# Altium schematic sheet CV-power.SchDoc
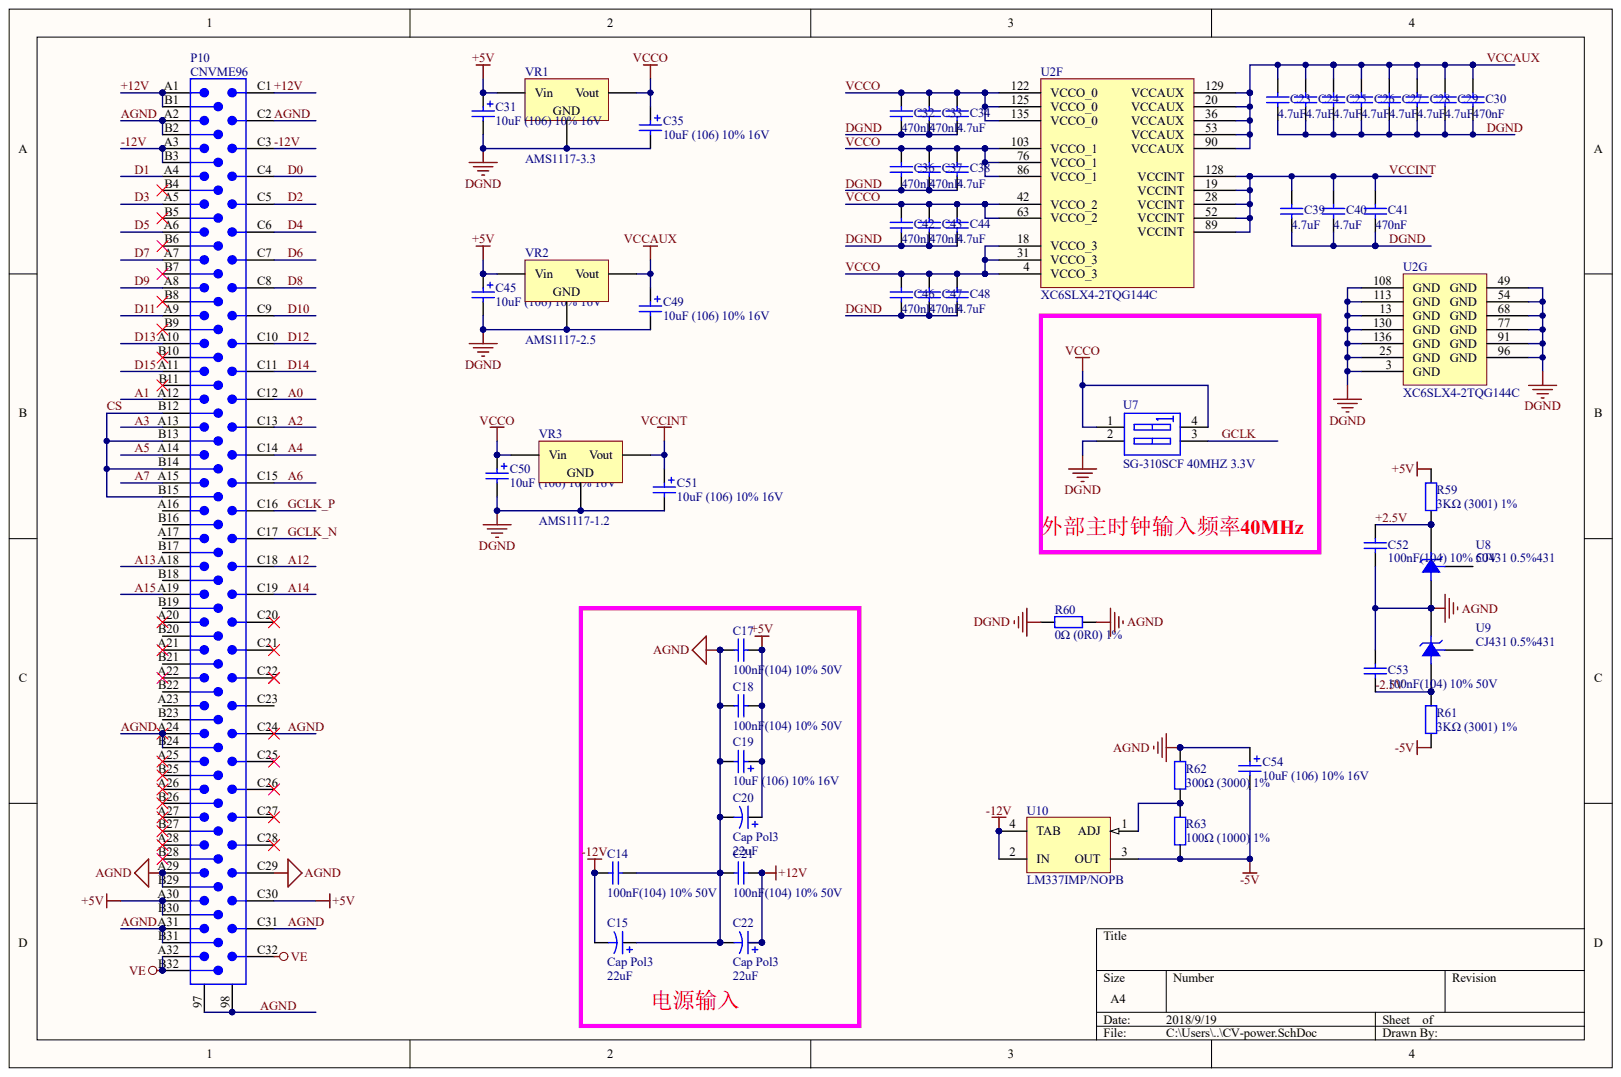
[diagram p0: CV-power.SchDoc]

## Parts
C14  100nF(104) 10% 50V
C15  Cap Pol3  value 22uF
C17  100nF(104) 10% 50V
C18  100nF(104) 10% 50V
C19  10uF (106) ±10% 16V
C20  Cap Pol3  value 22uF
C21  100nF(104) 10% 50V
C22  Cap Pol3  value 22uF
C23  4.7uF
C24  4.7uF
C25  4.7uF
C26  4.7uF
C27  4.7uF
C28  4.7uF
C29  4.7uF
C30  470nF
C31  10uF (106) ±10% 16V
C32  470nF
C33  470nF
C34  4.7uF
C35  10uF (106) ±10% 16V
C36  470nF
C37  470nF
C38  4.7uF
C39  4.7uF
C40  4.7uF
C41  470nF
C42  470nF
C43  470nF
C44  4.7uF
C45  10uF (106) ±10% 16V
C46  470nF
C47  470nF
C48  4.7uF
C49  10uF (106) ±10% 16V
C50  10uF (106) ±10% 16V
C51  10uF (106) ±10% 16V
C52  100nF(104) 10% 50V
C53  100nF(104) 10% 50V
C54  10uF (106) ±10% 16V
P10  CNVME96
R59  3KΩ (3001) ±1%  (value not drawn)
R60  0Ω (0R0) ±1%
R61  3KΩ (3001) ±1%  (value not drawn)
R62  300Ω (3000) ±1%
R63  100Ω (1000) ±1%
U2  XC6SLX4-2TQG144C  (parts F,G)
U7  SG-310SCF 40MHZ 3.3V
U8  CJ431 0.5%431
U9  CJ431 0.5%431
U10  LM337IMP/NOPB
VR1  AMS1117-3.3
VR2  AMS1117-2.5
VR3  AMS1117-1.2

## Nets
+5V: C17.2 C18.2 C19.1 C20.1 C31.1 C45.1 P10.A30 P10.B30 P10.C30 R59.2 VR1.3 VR2.3
-5V: C54.2 R61.1 R63.1 U10.3(OUT)
VE: P10.A32 P10.B32 P10.C32
+2.5V: C52.1 R59.1 U8.2
+12V: C21.2 C22.1 P10.A1 P10.B1 P10.C1
-2.5V: C53.2 R61.2 U9.3
-12V: C14.1 C15.2 P10.A3 P10.B3 P10.C3 U10.2(IN) U10.4(TAB)
A0: P10.C12
A1: P10.A12
A2: P10.C13
A3: P10.A13
A4: P10.C14
A5: P10.A14
A6: P10.C15
A7: P10.A15
A12: P10.C18
A13: P10.A18
A14: P10.C19
A15: P10.A19
AGND: C14.2 C15.1 C17.1 C18.1 C19.2 C20.2 C21.1 C22.2 C52.2 C53.1 C54.1 P10.97 P10.98 P10.A2 P10.A24 P10.A29 P10.A31 P10.B2 P10.B24 P10.B29 P10.B31 P10.C2 P10.C24 P10.C29 P10.C31 R60.2 R62.2 U8.3 U9.2
CS: P10.B12 P10.B13 P10.B14 P10.B15
D0: P10.C4
D1: P10.A4
D2: P10.C5
D3: P10.A5
D4: P10.C6
D5: P10.A6
D6: P10.C7
D7: P10.A7
D8: P10.C8
D9: P10.A8
D10: P10.C9
D11: P10.A9
D12: P10.C10
D13: P10.A10
D14: P10.C11
D15: P10.A11
DGND: C23.1 C24.1 C25.1 C26.1 C27.1 C28.1 C29.1 C30.1 C31.2 C32.1 C33.1 C34.1 C35.2 C36.1 C37.1 C38.1 C39.1 C40.1 C41.1 C42.1 C43.1 C44.1 C45.2 C46.1 C47.1 C48.1 C49.2 C50.2 C51.2 R60.1 U2.3(GND) U2.13(GND) U2.25(GND) U2.49(GND) U2.54(GND) U2.68(GND) U2.77(GND) U2.91(GND) U2.96(GND) U2.108(GND) U2.113(GND) U2.130(GND) U2.136(GND) U7.2 VR1.1 VR2.1 VR3.1
GCLK: U7.3
GCLK_N: P10.C17
GCLK_P: P10.C16
VCCAUX: C23.2 C24.2 C25.2 C26.2 C27.2 C28.2 C29.2 C30.2 C49.1 U2.20(VCCAUX) U2.36(VCCAUX) U2.53(VCCAUX) U2.90(VCCAUX) U2.129(VCCAUX) VR2.2
VCCINT: C39.2 C40.2 C41.2 C51.1 U2.19(VCCINT) U2.28(VCCINT) U2.52(VCCINT) U2.89(VCCINT) U2.128(VCCINT) VR3.2
VCCO: C32.2 C33.2 C34.2 C35.1 C36.2 C37.2 C38.2 C42.2 C43.2 C44.2 C46.2 C47.2 C48.2 C50.1 U2.4(VCCO_3) U2.18(VCCO_3) U2.31(VCCO_3) U2.42(VCCO_2) U2.63(VCCO_2) U2.76(VCCO_1) U2.86(VCCO_1) U2.103(VCCO_1) U2.122(VCCO_0) U2.125(VCCO_0) U2.135(VCCO_0) U7.1 U7.4 VR1.2 VR3.3
NetR62_1: R62.1 R63.2 U10.1(ADJ)

Not connected: P10 A16 A17 A20 A21 A22 A23 A25 A26 A27 A28 B4 B5 B6 B7 B8 B9 B10 B11 B16 B17 B18 B19 B20 B21 B22 B23 B25 B26 B27 B28 C20 C21 C22 C23 C25 C26 C27 C28; U8 1; U9 1

## Library data of the parts
C20 = (no symbol name): Value: 22uF; Published: 8-Jun-2000; LatestRevisionDate: 29-May-2009; LatestRevisionNote: IPC-7351 Footprint Added.; PackageReference: C0805; PackageDescription: Chip Capacitor; Publisher: Altium Limited
P10 = (no symbol name): Text Field6: Alternate Layout Available; Text Field7: Published 14-Feb-2002
U10 = (no symbol name): Manufacturer: National Semiconductor; PackageTopMarking: XYTT*N02A; PowerWise: N; CompType: LDO IC; Published: 1-Mar-2010; DatasheetVersion: Nov-2004; PackageVersion: revB, Apr-2003; PackageReference: MP04A; PackageDescription: Molded SOT-223, 6.50 x 3.56 x 1.6mm Body, 4 Lead, 2.3mm Pitch; Supplier 1: Digi-Key; Supplier Part Number 1: LM337IMPTR-ND; Supplier 2: Digi-Key; Supplier Part Number 2: LM337IMPCT-ND; Supplier 3: Digi-Key; Supplier Part Number 3: LM337IMPDKR-ND; Supplier 4: Newark; Supplier Part Number 4: 41K4742; PartNumber: LM337IMP/NOPB; RoHS: Y; PinCount: 4; Code_JEDEC: TO-261-AA; Code_IPC: SOT223-4; ComponentLink1Description: LM337 Datasheet; ComponentLink1URL: http://www.national.com/ds/LM/LM337.pdf; ComponentLink2Description: LM337 Web Page; ComponentLink2URL: http://www.national.com/pf/LM/LM337.html; ComponentLink3Description: MP04A Package Page; ComponentLink3URL: http://www.national.com/packaging/folders/mp04a.html; Publisher: Altium Limited
C14 C15 C17-C19 C21-C54 R59-R63 U2 U7-U9 VR1-VR3 = (no symbol name)
  C14 C17 C18 C21 C52 C53: ComponentLink1Description: 供应商链接; ComponentLink1URL: http://www.szlcsc.com/product/details_50686.html; Package: 0805; Supplier: LC; SuppliersPartNumber: C49678
  C15 C22: Published: 8-Jun-2000; LatestRevisionDate: 29-May-2009; LatestRevisionNote: IPC-7351 Footprint Added.; PackageReference: C0805; PackageDescription: Chip Capacitor
  C19 C31 C35 C45 C49-C51 C54: ComponentLink1Description: 供应商链接; ComponentLink1URL: http://www.szlcsc.com/product/details_7643.html; Package: 3216; Supplier: LC; SuppliersPartNumber: C7171
  C23-C29 C34 C38-C40 C44 C48: ComponentLink1Description: 供应商链接; ComponentLink1URL: http://www.szlcsc.com/product/details_2131.html; Package: 0805; Supplier: LC; SuppliersPartNumber: C1779
  C30 C32 C33 C36 C37 C41-C43 C46 C47: ComponentLink1Description: 供应商链接; ComponentLink1URL: http://www.szlcsc.com/product/details_14623.html; Package: 0805; Supplier: LC; SuppliersPartNumber: C13967
  R59 R61: ComponentLink1Description: 供应商链接; ComponentLink1URL: http://www.szlcsc.com/product/details_18349.html; Package: 0805; Supplier: LC; SuppliersPartNumber: C17661
  R60: ComponentLink1Description: 供应商链接; ComponentLink1URL: http://www.szlcsc.com/product/details_18165.html; Package: 0805; Supplier: LC; SuppliersPartNumber: C17477
  R62: ComponentLink1Description: 供应商链接; ComponentLink1URL: http://www.szlcsc.com/product/details_18305.html; Package: 0805; Supplier: LC; SuppliersPartNumber: C17617
  R63: ComponentLink1Description: 供应商链接; ComponentLink1URL: http://www.szlcsc.com/product/details_18096.html; Package: 0805; Supplier: LC; SuppliersPartNumber: C17408
  U2: Published: 1-Jul-2010; DatasheetVersion: ver1.6, Nov-2010; PackageVersion: ver1.2, Jun-2004; PackageReference: TQG144; PackageDescription: 144-Pin Thin Quad Flat Pack (TQG144) - Pb-Free; Supplier 1: Digi-Key; Supplier Part Number 1: XC6SLX4-2TQG144C-ND; Code_JEDEC: MS-026-BFB; ComponentLink1Description: Manufacturer Link; ComponentLink1URL: http://www.xilinx.com; ComponentLink2Description: Spartan-6 Datasheet; ComponentLink2URL: http://www.xilinx.com/support/documentation/data_sheets/ds160.pdf; ComponentLink3Description: Spartan-6 Documents; ComponentLink3URL: http://www.xilinx.com/support/documentation/spartan-6.htm; ComponentLink4Description: Xilinx.com Home; ComponentLink4URL: http://www.xilinx.com
  U7: Published: 24-Mar-1999; LatestRevisionDate: 17-Jul-2002; LatestRevisionNote: Re-released for DXP Platform.; PackageReference: DIP-4
  U8 U9: ComponentLink1Description: 供应商链接; ComponentLink1URL: http://www.szlcsc.com/product/details_3500.html; Package: SOT-23; Supplier: LC; SuppliersPartNumber: C3113
  VR1-VR3: ComponentLink1Description: 供应商链接; ComponentLink1URL: http://www.szlcsc.com/product/details_6652.html; Package: SOT-223; Supplier: LC; SuppliersPartNumber: C6186
  C15 C22 U2 U7: Publisher: Altium Limited
